# Altium schematic sheet Main.SchDoc(Main)
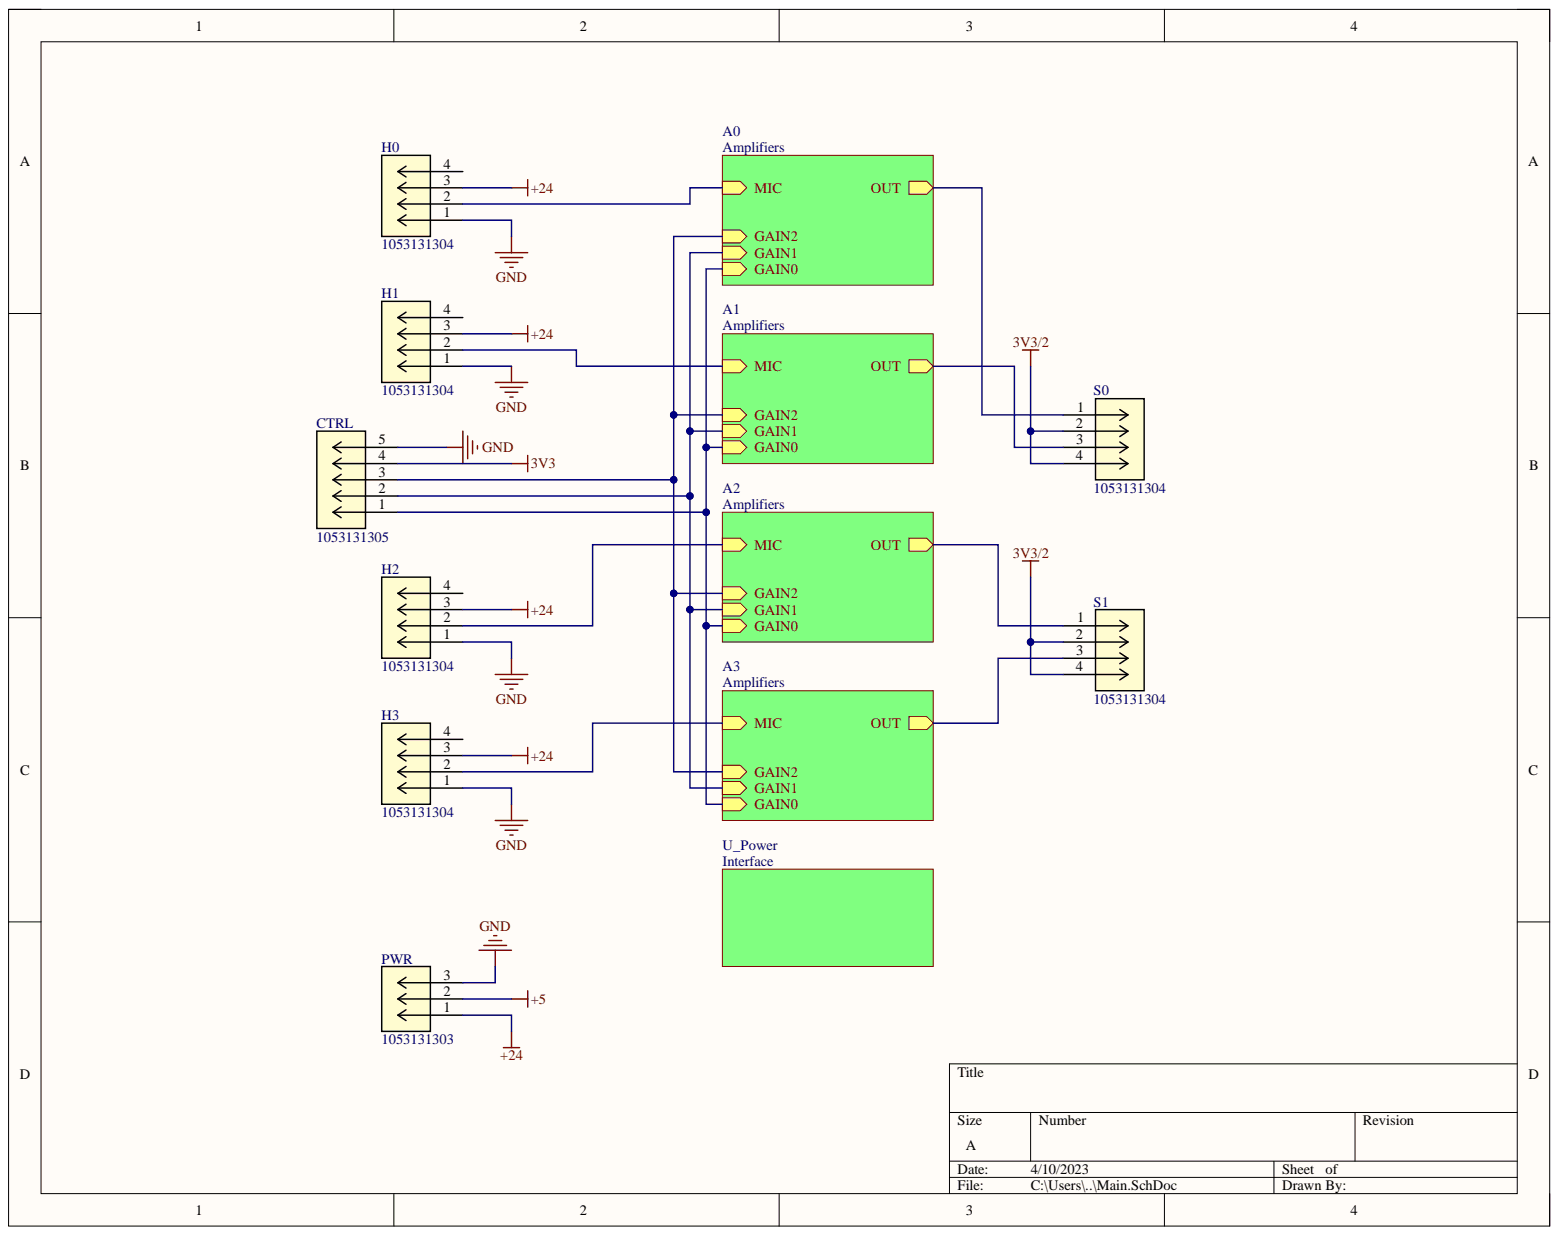
[diagram p0: Main.SchDoc]

## Parts
CTRL  1053131305  [CON_1053131305]
H0  1053131304  [CON_1053131304]
H1  1053131304  [CON_1053131304]
H2  1053131304  [CON_1053131304]
H3  1053131304  [CON_1053131304]
PWR  1053131303  [CON_1053131303]
S0  1053131304  [CON_1053131304]
S1  1053131304  [CON_1053131304]

## Nets
3V3: CTRL.4
3V3/2: S0.2 S0.4 S1.2 S1.4
+5: PWR.2
+24: H0.3 H1.3 H2.3 H3.3 PWR.1
GND: CTRL.5 H0.1 H1.1 H2.1 H3.1 PWR.3
NetCTRL_1: CTRL.1
NetCTRL_2: CTRL.2
NetCTRL_3: CTRL.3
NetH0_2: H0.2
NetH0_4: H0.4
NetH1_2: H1.2
NetH1_4: H1.4
NetH2_2: H2.2
NetH2_4: H2.4
NetH3_2: H3.2
NetH3_4: H3.4
NetS0_1: S0.1
NetS0_3: S0.3
NetS1_1: S1.1
NetS1_3: S1.3

## Library data of the parts
CTRL = 1053131305: No Description Available; Mfr_Name: Molex Connector Corporation; Component Kind: Standard; Library Name: 1053131305.SchLib; Manufacturer_Part_Number: 1053131305; RefDes: RefDes; Type: DEV; Copyright: Copyright (C) 2023 Ultra Librarian. All rights reserved.
H0-H3 S0 S1 = 1053131304: No Description Available; Mfr_Name: Molex Connector Corporation; Component Kind: Standard; Library Name: 1053131304.SchLib; Manufacturer_Part_Number: 1053131304; RefDes: RefDes; Type: DEV; Copyright: Copyright (C) 2023 Ultra Librarian. All rights reserved.
PWR = 1053131303: No Description Available; Mfr_Name: Molex Connector Corporation; Component Kind: Standard; Library Name: 1053131303.SchLib; Manufacturer_Part_Number: 1053131303; RefDes: RefDes; Type: DEV; Copyright: Copyright (C) 2023 Ultra Librarian. All rights reserved.
